# Altium schematic sheet IMU.SchDoc(IMU)
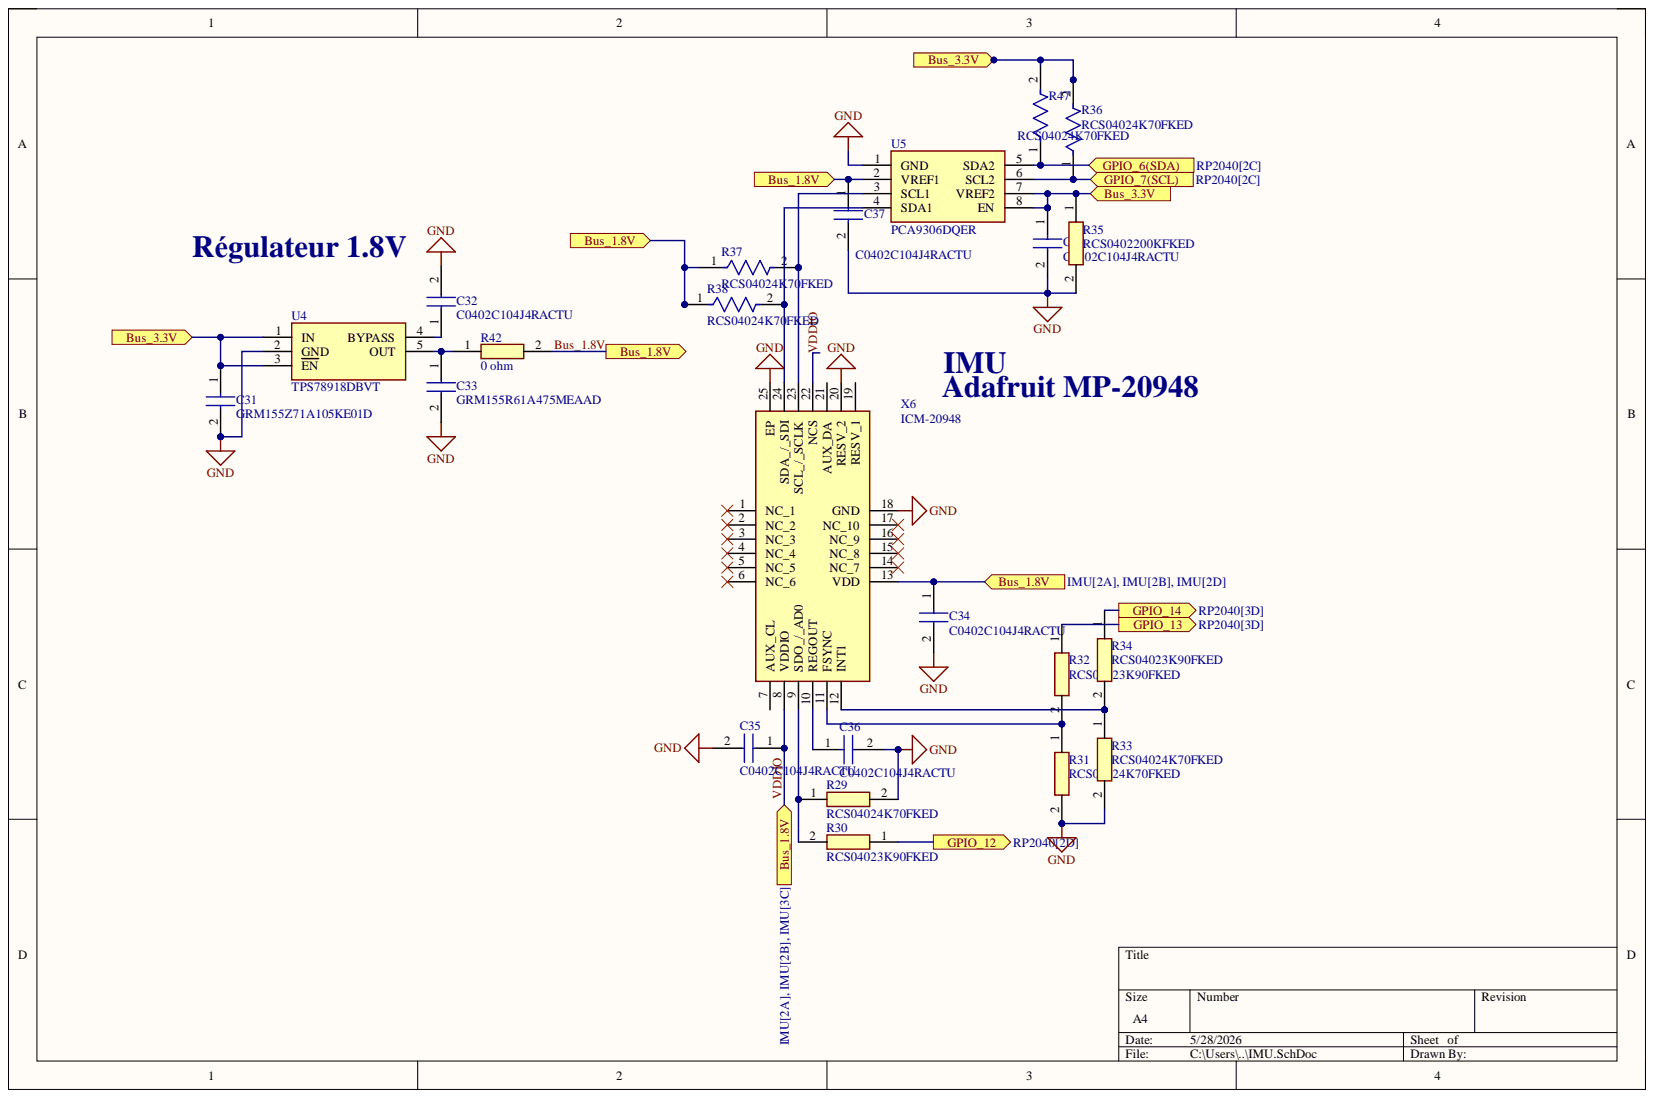
[diagram p0: IMU.SchDoc]

## Parts
C31  GRM155Z71A105KE01D  [GRM15x]
C32  C0402C104J4RACTU  [C0402C104J4RACTU]
C33  GRM155R61A475MEAAD  [CAPC1005X70N]
C34  C0402C104J4RACTU  [C0402C104J4RACTU]
C35  C0402C104J4RACTU  [C0402C104J4RACTU]
C36  C0402C104J4RACTU  [C0402C104J4RACTU]
C37  C0402C104J4RACTU  [C0402C104J4RACTU]
C38  C0402C104J4RACTU  [C0402C104J4RACTU]
R29  RCS04024K70FKED  [RCS0402_E3]
R30  RCS04023K90FKED  [RCS0402_E3]
R31  RCS04024K70FKED  [RCS0402_E3]
R32  RCS04023K90FKED  [RCS0402_E3]
R33  RCS04024K70FKED  [RCS0402_E3]
R34  RCS04023K90FKED  [RCS0402_E3]
R35  RCS0402200KFKED  [RCS0402_E3]
R36  RCS04024K70FKED  [FP-CR0402-MFG]
R37  RCS04024K70FKED  [FP-CR0402-MFG]
R38  RCS04024K70FKED  [FP-CR0402-MFG]
R42  0 ohm  [RCS0402_E3]
R47  RCS04024K70FKED  [FP-CR0402-MFG]
U4  TPS78918DBVT  [SOT95P280X145-5N]
U5  PCA9306DQER  [PCA9306DQER]
X6  ICM-20948  [QFN40P300X300X105-25N-D]

## Ports
Bus_1.8V, Bus_3.3V, GPIO_6(SDA), GPIO_7(SCL), GPIO_12, GPIO_13, GPIO_14

## Nets
GND: C31.2 C32.2 C33.2 C34.2 C35.2 C36.2 C37.2 C38.2 R29.2 R31.2 R33.2 R35.2 U4.2(GND) U5.1(GND) X6.18(GND) X6.20(RESV_2) X6.25(EP)
Bus_1.8V: C34.1 C35.1 C37.1 R37.1 R38.1 R42.2 U5.2(VREF1) X6.8(VDDIO) X6.13(VDD) X6.22(NCS) ; port Bus_1.8V
Bus_3.3V: C31.1 C38.1 R35.1 R36.2 R47.2 U4.1(IN) U4.3(EN) U5.7(VREF2) U5.8(EN) ; port Bus_3.3V
GPIO_6(SDA): R47.1 U5.5(SDA2) ; port GPIO_6(SDA)
GPIO_7(SCL): R36.1 U5.6(SCL2) ; port GPIO_7(SCL)
GPIO_12: R30.1 ; port GPIO_12
GPIO_13: R32.1 ; port GPIO_13
GPIO_14: R34.1 ; port GPIO_14
NetC32_1: C32.1 U4.4(BYPASS)
NetC33_1: C33.1 R42.1 U4.5(OUT)
NetC36_1: C36.1 X6.10(REGOUT)
NetR29_1: R29.1 R30.2 X6.9(SDO_/_AD0)
NetR31_1: R31.1 R32.2 X6.11(FSYNC)
NetR33_1: R33.1 R34.2 X6.12(INT1)
NetR37_2: R37.2 U5.3(SCL1) X6.23(SCL_/_SCLK)
NetR38_2: R38.2 U5.4(SDA1) X6.24(SDA_/_SDI)

Not connected: X6 1(NC_1) 2(NC_2) 3(NC_3) 4(NC_4) 5(NC_5) 6(NC_6) 7(AUX_CL) 14(NC_7) 15(NC_8) 16(NC_9) 17(NC_10) 19(RESV_1) 21(AUX_DA)

## Library data of the parts
C31 = GRM155Z71A105KE01D: Condensateur 1uF; Manufacturer_Name: Murata Electronics; Component Kind: Standard; Datasheet Link: https://search.murata.co.jp/Ceramy/image/img/A01X/G101/ENG/GRM155Z71A105KE01-01A.pdf; Height: 0.33mm; Manufacturer_Part_Number: GRM155Z71A105KE01D; Mouser Part Number: 81-GRM155Z71A105KE1D; Mouser Price/Stock: https://www.mouser.co.uk/ProductDetail/Murata-Electronics/GRM155Z71A105KE01D?qs=%252B6g0mu59x7LZ5VDL%2FTet%2Fg%3D%3D; Library Name: ADSC_V2.IntLib
C32 C34-C38 = C0402C104J4RACTU: Condensateur 100nF; Manufacturer_Name: KEMET; Arrow Part Number: C0402C104J4RACTU; Arrow Price/Stock: https://www.arrow.com/en/products/c0402c104j4ractu/kemet-corporation?utm_currency=USD&region=nac; Component Kind: Standard; Datasheet Link: https://content.kemet.com/datasheets/KEM_C1002_X7R_SMD.pdf; Height: 0.55mm; Manufacturer_Part_Number: C0402C104J4RACTU; Mouser Part Number: 80-C0402C104J4R; Mouser Price/Stock: https://www.mouser.co.uk/ProductDetail/KEMET/C0402C104J4RACTU?qs=hOC58ESJ5%2FTi6zdFDTVLPg%3D%3D; Library Name: ADSC_V2.IntLib
C33 = GRM155R61A475MEAAD: Capacitor; Manufacturer_Name: Murata Electronics; Arrow Part Number: GRM155R61A475MEAAD; Arrow Price/Stock: https://www.arrow.com/en/products/grm155r61a475meaad/murata-manufacturing?utm_currency=USD&region=nac; Component Kind: Standard; Datasheet Link: https://search.murata.co.jp/Ceramy/image/img/A01X/G101/ENG/GRM155R61A475MEAA-01A.pdf; Height: 0.7mm; Manufacturer_Part_Number: GRM155R61A475MEAAD; Mouser Part Number: 81-GRM155R61A475MEAD; Mouser Price/Stock: https://www.mouser.co.uk/ProductDetail/Murata-Electronics/GRM155R61A475MEAAD?qs=AEetWsPH0IuXrVCc8yqzOA%3D%3D; Library Name: ADSC_V2.IntLib
R29 R31 R33 = RCS04024K70FKED: Résistance 4.7kohm; Manufacturer_Name: Vishay; Arrow Part Number: RCS04024K70FKED; Arrow Price/Stock: https://www.arrow.com/en/products/rcs04024k70fked/vishay?utm_currency=USD&region=nac; Component Kind: Standard; Datasheet Link: https://www.vishay.com/docs/20065/rcse3.pdf; Manufacturer_Part_Number: RCS04024K70FKED; Mouser Part Number: 71-RCS04024K70FKED; Mouser Price/Stock: https://www.mouser.co.uk/ProductDetail/Vishay-Dale/RCS04024K70FKED?qs=NKmfXavxMaw%252Bu6VUO3JuMQ%3D%3D; Library Name: ADSC_V2.IntLib
R30 R32 R34 = RCS04023K90FKED: Resistor; Manufacturer_Name: Vishay; Component Kind: Standard; Datasheet Link: https://www.vishay.com/docs/20065/rcse3.pdf; Manufacturer_Part_Number: RCS04023K90FKED; Mouser Part Number: 71-RCS04023K90FKED; Mouser Price/Stock: https://www.mouser.co.uk/ProductDetail/Vishay-Dale/RCS04023K90FKED/?qs=6AVTNucw1PUThxozkd%252BRhw%3D%3D; Library Name: ADSC_V2.IntLib
R35 = RCS0402200KFKED: Resistor; Manufacturer_Name: Vishay; Arrow Part Number: RCS0402200KFKED; Arrow Price/Stock: https://www.arrow.com/en/products/rcs0402200kfked/vishay?region=nac; Component Kind: Standard; Datasheet Link: https://www.vishay.com/docs/20065/rcse3.pdf; Manufacturer_Part_Number: RCS0402200KFKED; Mouser Part Number: 71-RCS0402200KFKED; Mouser Price/Stock: https://www.mouser.co.uk/ProductDetail/Vishay-Dale/RCS0402200KFKED?qs=yDQnu9hAfI%2FealnERnvG6g%3D%3D; Library Name: ADSC_V2.IntLib
R36-R38 R47 = CMP-2002-05461-2: Thick Film Chip Resistors 0402 91kΩ 0.0625W 1% 100ppm/°C; Max Power Dissipation: 63mW; Power Rating: 62.5mW; Resistance: 91kR; Voltage Rating: 50V; Case Code (Imperial): 0402; Case Code (Metric): 1005; Case/Package: 0402; Component Kind: Standard; Composition: Thick Film; Contact Plating: Tin; Depth: 0.5mm; Height: 0.016inch; Length: 1mm; Library Name: Altium Content Vault; Max Operating Temperature: 125°C; Military Standard: Not; Min Operating Temperature: -55°C; Mount: Surface Mount; Number of Terminations: 2; Package Quantity: 10000; Packaging: Tape and Reel; Radiation Hardening: No; Temperature Coefficient: 100ppm/°C; Termination: SMD/SMT; Thickness: 356um; Tolerance: 1%; Width: 0.02inch
R42 = RCS0402100RFKED: Resistor; Manufacturer_Name: Vishay; Arrow Part Number: RCS0402100RFKED; Arrow Price/Stock: https://www.arrow.com/en/products/rcs0402100rfked/vishay?utm_currency=USD&region=nac; Component Kind: Standard; Datasheet Link: https://www.vishay.com/docs/20065/rcse3.pdf; Manufacturer_Part_Number: RCS0402100RFKED; Mouser Part Number: 71-RCS0402100RFKED; Mouser Price/Stock: https://www.mouser.co.uk/ProductDetail/Vishay-Dale/RCS0402100RFKED?qs=NKmfXavxMaykuHEaJBK0%252BA%3D%3D; Library Name: ADSC_V2.IntLib
U4 = TPS78918DBVT: Power Supply; Manufacturer_Name: Texas Instruments; Arrow Part Number: TPS78918DBVT; Arrow Price/Stock: https://www.arrow.com/en/products/tps78918dbvt/texas-instruments; Component Kind: Standard; Datasheet Link: http://www.ti.com/lit/ds/symlink/tps789.pdf; Height: 1.45mm; Manufacturer_Part_Number: TPS78918DBVT; Mouser Part Number: 595-TPS78918DBVT; Mouser Price/Stock: https://www.mouser.com/Search/Refine.aspx?Keyword=595-TPS78918DBVT; Library Name: ADSC_V2.IntLib
U5 = PCA9306DQER: Integrated Circuit; Manufacturer_Name: Texas Instruments; Arrow Part Number: PCA9306DQER; Arrow Price/Stock: https://www.arrow.com/en/products/pca9306dqer/texas-instruments?utm_currency=USD&region=nac; Component Kind: Standard; Datasheet Link: http://www.ti.com/lit/ds/symlink/pca9306.pdf; Height: 0.4mm; Manufacturer_Part_Number: PCA9306DQER; Mouser Part Number: 595-PCA9306DQER; Mouser Price/Stock: https://www.mouser.co.uk/ProductDetail/Texas-Instruments/PCA9306DQER?qs=g5raLcSarOb3s8X2FUFJYw%3D%3D; Library Name: ADSC_V2.IntLib
X6 = ICM-20948: Integrated Circuit; Manufacturer_Name: TDK; Arrow Part Number: ICM-20948; Arrow Price/Stock: https://www.arrow.com/en/products/icm-20948/invensense?utm_currency=USD&region=nac; Component Kind: Standard; Datasheet Link: https://product.tdk.com/system/files/dam/doc/product/sensor/mortion-inertial/imu/data_sheet/ds-000189-icm-20948-v1.3.pdf; Height: 1.05mm; Manufacturer_Part_Number: ICM-20948; Mouser Part Number: 410-ICM-20948; Mouser Price/Stock: https://www.mouser.co.uk/ProductDetail/TDK-InvenSense/ICM-20948?qs=u4fy%2FsgLU9OtGc31yF%2Friw%3D%3D; Library Name: ADSC_V2.IntLib
